# Altium schematic sheet MIDI-Keeb_Sch.SchDoc
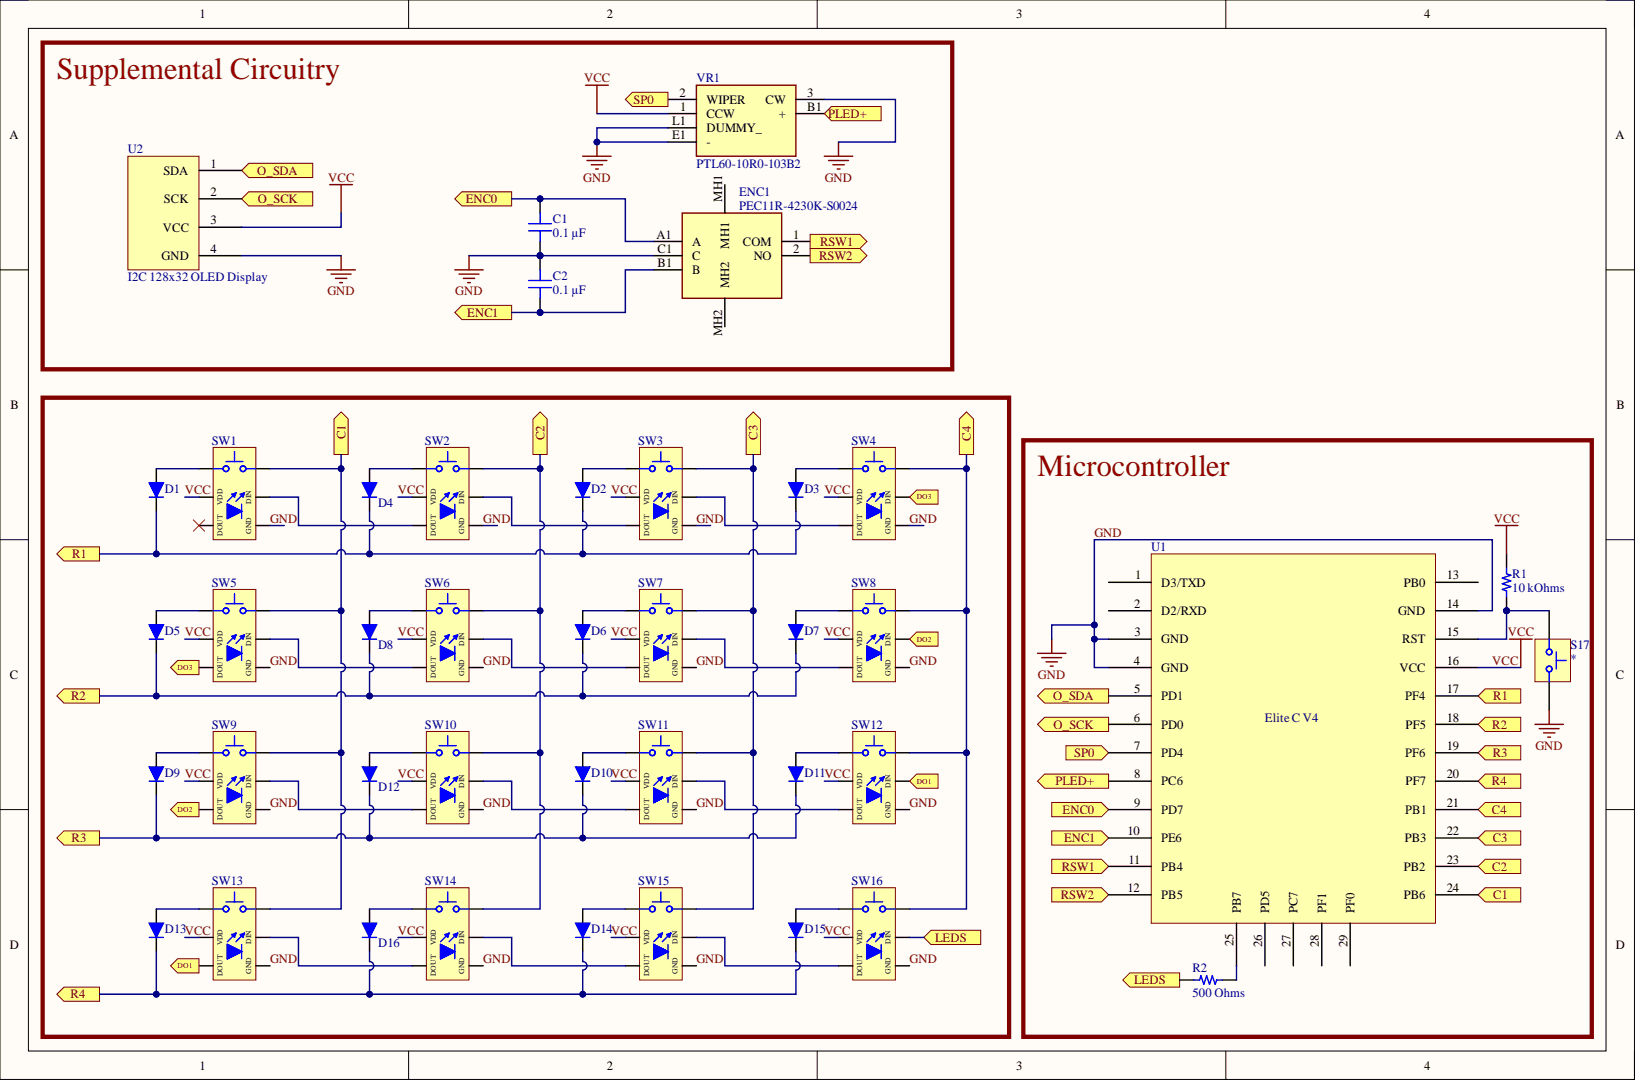
[diagram p0: MIDI-Keeb_Sch.SchDoc]

## Parts
C1  0.1 µF  [CAP 0805_2012]
C2  0.1 µF  [CAP 0805_2012]
D1  1N4148  [SOD-123-K1A2]  (value not drawn)
D2  1N4148  [SOD-123-K1A2]  (value not drawn)
D3  1N4148  [SOD-123-K1A2]  (value not drawn)
D4  1N4148  [SOD-123-K1A2]  (value not drawn)
D5  1N4148  [SOD-123-K1A2]  (value not drawn)
D6  1N4148  [SOD-123-K1A2]  (value not drawn)
D7  1N4148  [SOD-123-K1A2]  (value not drawn)
D8  1N4148  [SOD-123-K1A2]  (value not drawn)
D9  1N4148  [SOD-123-K1A2]  (value not drawn)
D10  1N4148  [SOD-123-K1A2]  (value not drawn)
D11  1N4148  [SOD-123-K1A2]  (value not drawn)
D12  1N4148  [SOD-123-K1A2]  (value not drawn)
D13  1N4148  [SOD-123-K1A2]  (value not drawn)
D14  1N4148  [SOD-123-K1A2]  (value not drawn)
D15  1N4148  [SOD-123-K1A2]  (value not drawn)
D16  1N4148  [SOD-123-K1A2]  (value not drawn)
ENC1  PEC11R-4230K-S0024  [PEC11R4230KS0024]
R1  10 kOhms  [RES 0805_2012]
R2  500 Ohms  [RES 1206_3216]
S17  SW Tactile  [SW-TACT-SMD-6035]  (value not drawn)
SW1  -SW-KEYBOARD-WS2812B  [CHOC-HOTSWAP-WS2812B]  (value not drawn)
SW2  -SW-KEYBOARD-WS2812B  [CHOC-HOTSWAP-WS2812B]  (value not drawn)
SW3  -SW-KEYBOARD-WS2812B  [CHOC-HOTSWAP-WS2812B]  (value not drawn)
SW4  -SW-KEYBOARD-WS2812B  [CHOC-HOTSWAP-WS2812B]  (value not drawn)
SW5  -SW-KEYBOARD-WS2812B  [CHOC-HOTSWAP-WS2812B]  (value not drawn)
SW6  -SW-KEYBOARD-WS2812B  [CHOC-HOTSWAP-WS2812B]  (value not drawn)
SW7  -SW-KEYBOARD-WS2812B  [CHOC-HOTSWAP-WS2812B]  (value not drawn)
SW8  -SW-KEYBOARD-WS2812B  [CHOC-HOTSWAP-WS2812B]  (value not drawn)
SW9  -SW-KEYBOARD-WS2812B  [CHOC-HOTSWAP-WS2812B]  (value not drawn)
SW10  -SW-KEYBOARD-WS2812B  [CHOC-HOTSWAP-WS2812B]  (value not drawn)
SW11  -SW-KEYBOARD-WS2812B  [CHOC-HOTSWAP-WS2812B]  (value not drawn)
SW12  -SW-KEYBOARD-WS2812B  [CHOC-HOTSWAP-WS2812B]  (value not drawn)
SW13  -SW-KEYBOARD-WS2812B  [CHOC-HOTSWAP-WS2812B]  (value not drawn)
SW14  -SW-KEYBOARD-WS2812B  [CHOC-HOTSWAP-WS2812B]  (value not drawn)
SW15  -SW-KEYBOARD-WS2812B  [CHOC-HOTSWAP-WS2812B]  (value not drawn)
SW16  -SW-KEYBOARD-WS2812B  [CHOC-HOTSWAP-WS2812B]  (value not drawn)
U1  Elite C V4  [Elite-C-V4]
U2  I2C 128x32 OLED Display  [OLED_Headers]
VR1  PTL60-10R0-103B2  [PTL6010R0103B2]

## Ports
C1, C2, C3, C4, DO1, DO2, DO3, ENC0, ENC1, LEDS, O_SCK, O_SDA, PLED+, R1, R2, R3, R4, RSW1, RSW2, SP0

## Nets
C1: SW1.2 SW5.2 SW9.2 SW13.2 U1.24(PB6) ; port C1
C2: SW2.2 SW6.2 SW10.2 SW14.2 U1.23(PB2) ; port C2
C3: SW3.2 SW7.2 SW11.2 SW15.2 U1.22(PB3) ; port C3
C4: SW4.2 SW8.2 SW12.2 SW16.2 U1.21(PB1) ; port C4
DO1: SW12.4 SW13.5 ; port DO1
DO2: SW8.4 SW9.5 ; port DO2
DO3: SW4.4 SW5.5 ; port DO3
ENC0: C1.2 ENC1.A1(A) U1.9(PD7) ; port ENC0
ENC1: C2.1 ENC1.B1(B) U1.10(PE6) ; port ENC1
GND: C1.1 C2.2 ENC1.C1(C) S17.2 SW1.6 SW2.6 SW3.6 SW4.6 SW5.6 SW6.6 SW7.6 SW8.6 SW9.6 SW10.6 SW11.6 SW12.6 SW13.6 SW14.6 SW15.6 SW16.6 U1.3(GND) U1.4(GND) U1.14(GND) U2.4(GND) VR1.3(CW) VR1.E1(-) VR1.L1(DUMMY_)
LEDS: R2.1 SW16.4 ; port LEDS
O_SCK: U1.6(PD0) U2.2(SCK) ; port O_SCK
O_SDA: U1.5(PD1) U2.1(SDA) ; port O_SDA
PLED+: U1.8(PC6) VR1.B1(+) ; port PLED+
R1: D1.1 D2.1 D3.1 D4.1 U1.17(PF4) ; port R1
R2: D5.1 D6.1 D7.1 D8.1 U1.18(PF5) ; port R2
R3: D9.1 D10.1 D11.1 D12.1 U1.19(PF6) ; port R3
R4: D13.1 D14.1 D15.1 D16.1 U1.20(PF7) ; port R4
RSW1: ENC1.1(COM) U1.11(PB4) ; port RSW1
RSW2: ENC1.2(NO) U1.12(PB5) ; port RSW2
SP0: U1.7(PD4) VR1.2(WIPER) ; port SP0
VCC: R1.2 SW1.3 SW2.3 SW3.3 SW4.3 SW5.3 SW6.3 SW7.3 SW8.3 SW9.3 SW10.3 SW11.3 SW12.3 SW13.3 SW14.3 SW15.3 SW16.3 U1.16(VCC) U2.3(VCC) VR1.1(CCW)
NetD1_2: D1.2 SW1.1
NetD2_2: D2.2 SW3.1
NetD3_2: D3.2 SW4.1
NetD4_2: D4.2 SW2.1
NetD5_2: D5.2 SW5.1
NetD6_2: D6.2 SW7.1
NetD7_2: D7.2 SW8.1
NetD8_2: D8.2 SW6.1
NetD9_2: D9.2 SW9.1
NetD10_2: D10.2 SW11.1
NetD11_2: D11.2 SW12.1
NetD12_2: D12.2 SW10.1
NetD13_2: D13.2 SW13.1
NetD14_2: D14.2 SW15.1
NetD15_2: D15.2 SW16.1
NetD16_2: D16.2 SW14.1
NetR1_1: R1.1 S17.1 U1.15(RST)
NetR2_2: R2.2 U1.25(PB7)
NetSW1_4: SW1.4 SW2.5
NetSW2_4: SW2.4 SW3.5
NetSW3_4: SW3.4 SW4.5
NetSW5_4: SW5.4 SW6.5
NetSW6_4: SW6.4 SW7.5
NetSW7_4: SW7.4 SW8.5
NetSW9_4: SW9.4 SW10.5
NetSW10_4: SW10.4 SW11.5
NetSW11_4: SW11.4 SW12.5
NetSW13_4: SW13.4 SW14.5
NetSW14_4: SW14.4 SW15.5
NetSW15_4: SW15.4 SW16.5

Not connected: ENC1 MH1 MH2; SW1 5; U1 1(D3/TXD) 2(D2/RXD) 13(PB0) 26(PD5) 27(PC7) 28(PF1) 29(PF0)

## Library data of the parts
C1 C2 = CC0805MRX7R9BB104: CAP CER 0.1UF 50V X7R 0805; manufacturer: Yageo; Database Table Name: Capacitors - Ceramic - 0805; Tolerance: ±20%; Applications: General Purpose; Capacitance: 0.1 µF; Component Kind: Standard; componentlink1description: Datasheet; componentlink1url: https://www.yageo.com/upload/media/product/productsearch/datasheet/mlcc/UPY-GPHC_X7R_6.3V-to-50V_18.pdf; componentlink2description: DigiKey Link; componentlink2url: https://www.digikey.com/en/products/detail/yageo/CC0805MRX7R9BB104/5884281; Device Package: 0805 (2012 Metric); lastupdated: 2/25/2022 4:56:57 AM; Library Name: Celestial Altium Library - altium_library.DbLib; Lifecycle Status: Active; Minimum Order: 1; Mounting Type: Surface Mount, MLCC; Operating Temperature: -55°C ~ 125°C; Package / Case: 0805 (2012 Metric); packaging: TapeAndReel; Part Number: CC0805MRX7R9BB104; Part Status: Active; partid: 2475; price: 0.02; series: CC; Size / Dimension: 0.079" L x 0.049" W (2.00mm x 1.25mm); Supplier 1: DigiKey; Supplier Part Number 1: 311-4351-1-ND; Temperature Coefficient: X7R; Thickness (Max): 0.037" (0.95mm); Value: 0.1 µF; Voltage - Rated: 50V
D1-D16 = 1N4148W-7-F: 2 Pin Diode K1A2; Component Kind: Standard; Library Name: Semiconductors.IntLib; Supplier 1: Mouser; Supplier Part Number 1: 621-1N4148W-F; Value: 1N4148
ENC1 = PEC11R-4230K-S0024: Integrated Circuit; Manufacturer_Name: Bourns; Component Kind: Standard; Datasheet Link: https://www.mouser.co.uk/datasheet/2/54/PEC11R-777457.pdf; Height: 36.5mm; Manufacturer_Part_Number: PEC11R-4230K-S0024
R1 = RNCS0805BKE10K0: RES 10K OHM 0.1% 1/10W 0805; Manufacturer: Stackpole Electronics Inc; Database Table Name: Resistors - Surface Mount - 0805; Power (Watts): 0.1W, 1/10W; Temperature Coefficient: ±25ppm/°C; Component Kind: Standard; ComponentLink1Description: Datasheet; ComponentLink1URL: https://www.seielect.com/catalog/sei-rncs_rnch.pdf; ComponentLink2Description: DigiKey Link; ComponentLink2URL: https://www.digikey.com/en/products/detail/stackpole-electronics-inc/RNCS0805BKE10K0/1800067; Composition: Thin Film; Device Package: 0805 (2012 Metric); Features: Anti-Corrosive, Automotive AEC-Q200, Moisture Resistant; Height - Seated (Max): 0.026" (0.65mm); LastUpdated: 2/26/2022 8:58:25 AM; Library Name: Celestial Altium Library - altium_library.DbLib; Lifecycle Status: Active; Minimum Order: 1; Number of Terminations: 2; Operating Temperature: -55°C ~ 155°C; Package / Case: 0805 (2012 Metric); Packaging: TapeAndReel; Part Number: RNCS0805BKE10K0; Part Status: Active; PartId: 27713; Price: 0.09; Ratings: AEC-Q200; Resistance: 10 kOhms; Series: RNCS; Size / Dimension: 0.079" L x 0.049" W (2.00mm x 1.25mm); Supplier 1: DigiKey; Supplier Device Package: 0805; Supplier Part Number 1: RNCS0805BKE10K0CT-ND; Tolerance: ±0.1%; Value: 10 kOhms
R2 = TNPU1206500RAZEN00: RES SMD 500 OHM 0.05% 1/4W 1206; Manufacturer: Vishay Dale; Database Table Name: Resistors - Surface Mount - 1206; Power (Watts): 0.25W, 1/4W; Temperature Coefficient: ±5ppm/°C; Component Kind: Standard; ComponentLink1Description: Datasheet; ComponentLink1URL: http://www.vishay.com/docs/28779/tnpue3.pdf; ComponentLink2Description: DigiKey Link; ComponentLink2URL: http://digikey.com/product-detail/en/vishay-dale/TNPU1206500RAZEN00/541-3306-1-ND/6615963; Composition: Thin Film; Device Package: 1206 (3216 Metric); Features: Anti-Sulfur, Automotive AEC-Q200, Moisture Resistant; Height - Seated (Max): 0.026" (0.65mm); LastUpdated: 3/17/2022 8:47:42 AM; Library Name: Celestial Altium Library - altium_library.DbLib; Lifecycle Status: Active; Minimum Order: 1; Number of Terminations: 2; Operating Temperature: -55°C ~ 125°C; Package / Case: 1206 (3216 Metric); Packaging: TapeAndReel; Part Number: TNPU1206500RAZEN00; Part Status: Active; PartId: 30495; Price: 1.65; Ratings: AEC-Q200; Resistance: 500 Ohms; Series: TNPU; Size / Dimension: 0.126" L x 0.063" W (3.20mm x 1.60mm); Supplier 1: DigiKey; Supplier Device Package: 1206; Supplier Part Number 1: 541-3306-1-ND; Tolerance: ±0.05%; Value: 500 Ohms
S17 = SW-NO (PTS635SH43SMTRLFS): SPST, Normally Open, Push Button; Component Kind: Standard; Library Name: Switches.SchLib; Supplier 1: Mouser; Supplier Part Number 1: 611-PTS635SH43SMTRLF; Value: PTS635SH43SMTRLFS
U1 = Elite-C-V4: Elite C V4 ATMEGA32U4 Based Embedded MCU; Component Kind: Standard; Library Name: MIDI-Keeb-SCHLIB.SchLib
U2 = OLED_Board: Component Kind: Standard; Library Name: MIDI-Keeb-SCHLIB.SchLib
VR1 = PTL60-10R0-103B2: Variable Resistor; Manufacturer_Name: Bourns; Component Kind: Standard; Datasheet Link: https://www.arrow.com/en/products/ptl60-10r0-103b2/bourns; Height: 18mm; Library Name: SamacSys.SchLib; Manufacturer_Part_Number: PTL60-10R0-103B2
SW1-SW16 = -SW-KEYBOARD-WS2812B: Component Kind: Standard; Library Name: Switches.SchLib
